# Altium schematic sheet 8.LIS3MDLTR.SchDoc(8.LIS3MDLTR)
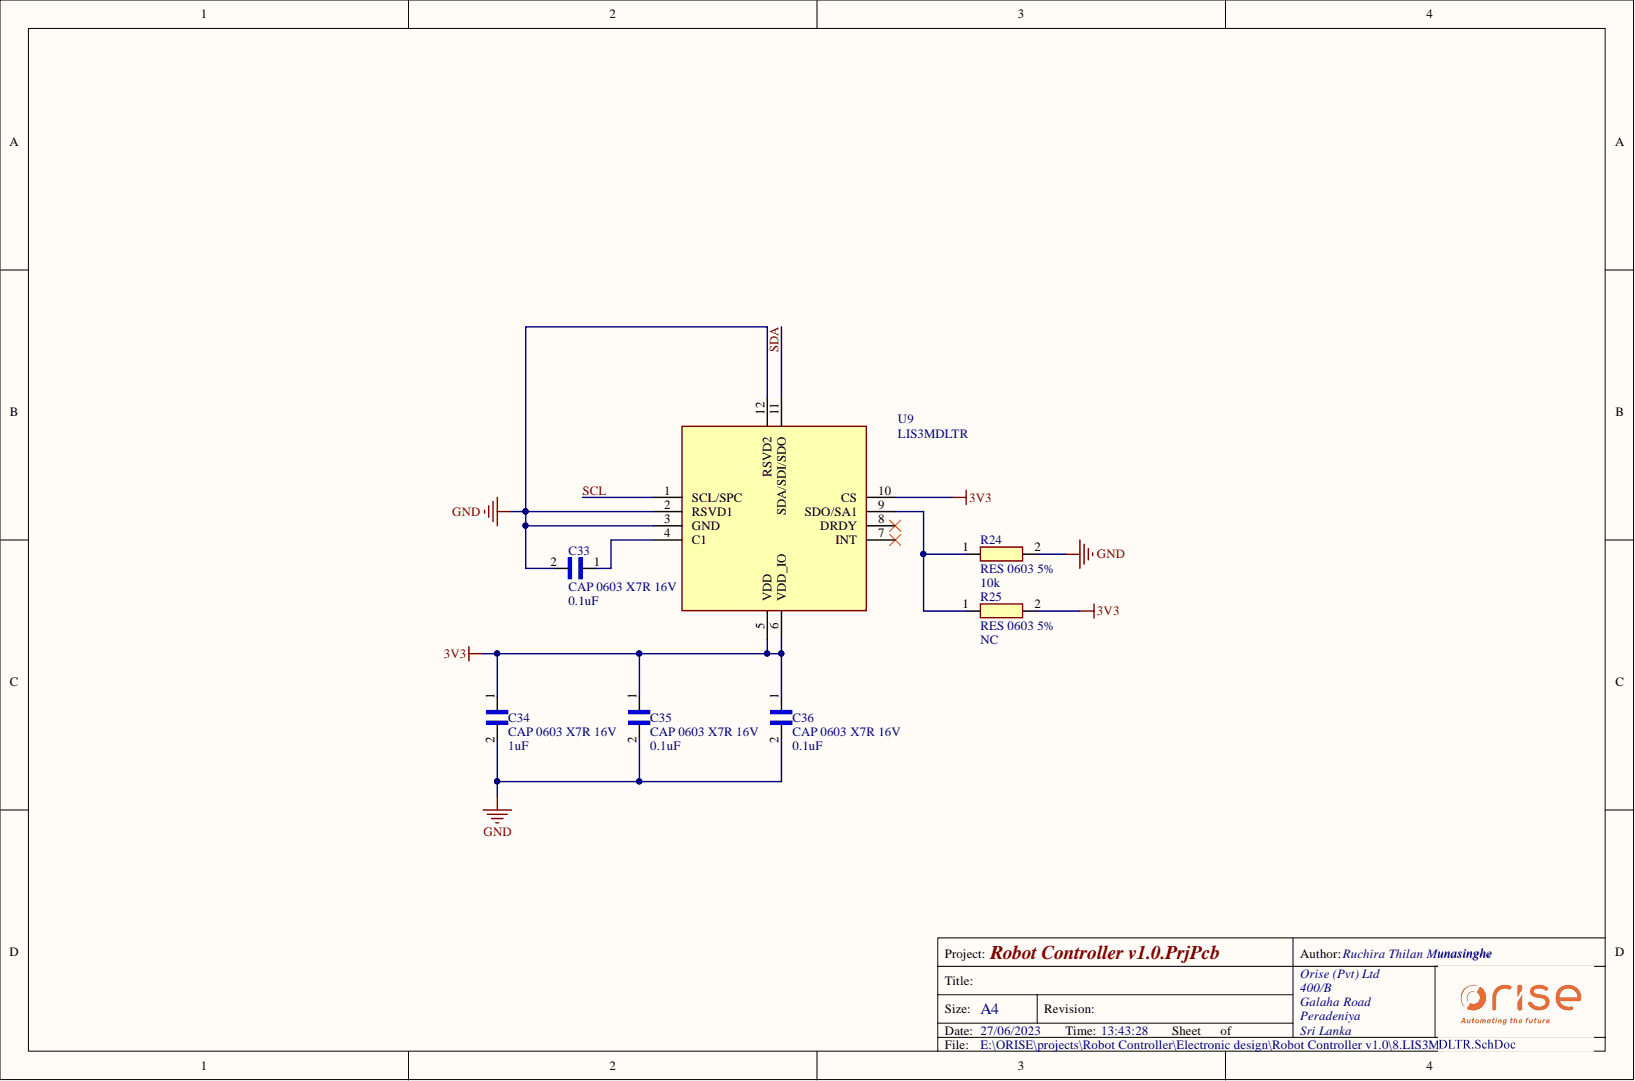
[diagram p0: 8.LIS3MDLTR.SchDoc]

## Parts
C33  CAP 0603 X7R 16V  value 0.1uF  [CAP 0603]
C34  CAP 0603 X7R 16V  value 1uF  [CAP 0603]
C35  CAP 0603 X7R 16V  value 0.1uF  [CAP 0603]
C36  CAP 0603 X7R 16V  value 0.1uF  [CAP 0603]
R24  RES 0603 5%  value 10k  [RES 0603]
R25  RES 0603 5%  value NC  [RES 0603 NC]
U9  LIS3MDLTR  [LIS3MDLTR]

## Nets
3V3: C34.1 C35.1 C36.1 R25.2 U9.5(VDD) U9.6(VDD_IO) U9.10(CS)
GND: C33.2 C34.2 C35.2 C36.2 R24.2 U9.2(RSVD1) U9.3(GND) U9.12(RSVD2)
SCL: U9.1(SCL/SPC)
SDA: U9.11(SDA/SDI/SDO)
NetC33_1: C33.1 U9.4(C1)
NetR24_1: R24.1 R25.1 U9.9(SDO/SA1)

Not connected: U9 7(INT) 8(DRDY)

## Library data of the parts
R24 = RES 0603 5%: value: 10k; Library Name: Robot Controller v1.0.SchLib; Description: RES 0603 5%; Component Kind: Standard
R25 = RES 0603 5% NC: Library Name: Robot Controller v1.0.SchLib; Description: RES 0603 5%; Component Kind: Standard
U9 = LIS3MDLTR: Magnetoresistive Sensor X, Y, Z Axis 12-LGA _2x2_; MF: STMicroelectronics; SnapEDA_Link: https://www.snapeda.com/parts/LIS3MDLTR/STMicroelectronics/view-part/382740/?ref=snap; Price: None; Package: VFLGA-12 STMicroelectronics; MP: LIS3MDLTR; Library Name: Robot Controller v1.0.SchLib; Component Kind: Standard; Availability: Unavailable
C33-C36 = CAP 0603 X7R 16V: Library Name: Robot Controller v1.0.SchLib; Description: CAP 0603 X7R 16V; Component Kind: Standard
